# Altium schematic sheet GPRS.SchDoc(GPRS)
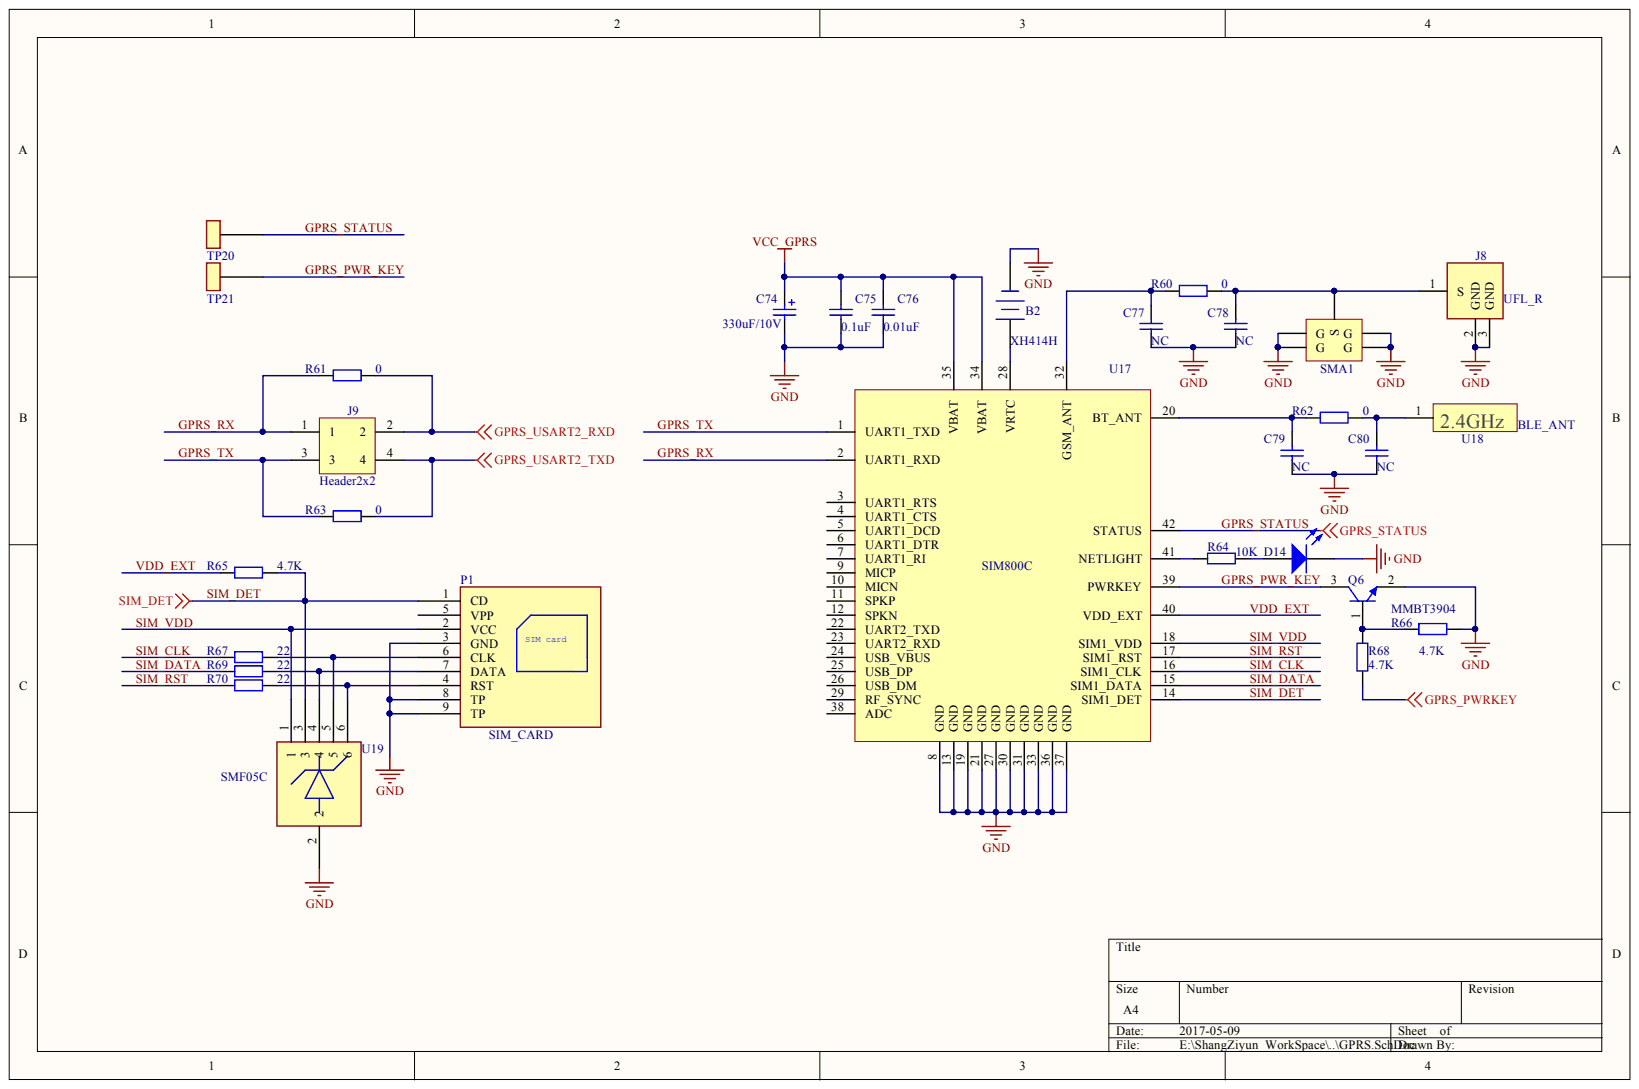
[diagram p0: GPRS.SchDoc]

## Parts
B2  B?  value XH414H  [XH414H]
C74  C?  value 330uF/10V  [EIA2816P]
C75  value 0.1uF  [0603C]  (hidden comment: Capacitor)
C76  value 0.01uF  [0603C]  (hidden comment: Capacitor)
C77  value NC  [0603C]  (hidden comment: Capacitor)
C78  value NC  [0603C]  (hidden comment: Capacitor)
C79  value NC  [0603C]  (hidden comment: Capacitor)
C80  value NC  [0603C]  (hidden comment: Capacitor)
D14  LED0  [LED_3mm]  (value not drawn)
J8  value UFL_R  [UFL_R_SMT]  (hidden comment: SMA_0)
J9  Header2x2  [DIP4]
P1  value SIM_CARD  [SIM060820MM-7P]  (hidden comment: SIM card_7P_ZT)
Q6  Q?  value MMBT3904  [SOT23]
R60  value 0  [L0603]  (hidden comment: Resistor)
R61  value 0  [L0603]  (hidden comment: Resistor)
R62  value 0  [L0603]  (hidden comment: Resistor)
R63  value 0  [L0603]  (hidden comment: Resistor)
R64  value 10K  [L0603]  (hidden comment: Resistor)
R65  value 4.7K  [L0603]  (hidden comment: Resistor)
R66  value 4.7K  [L0603]  (hidden comment: Resistor)
R67  value 22  [L0603]  (hidden comment: Resistor)
R68  value 4.7K  [L0603]  (hidden comment: Resistor)
R69  value 22  [L0603]  (hidden comment: Resistor)
R70  value 22  [L0603]  (hidden comment: Resistor)
SMA1  SMA  [SMA-KWE]
TP20  TEST POINT_0  [TP]  (value not drawn)
TP21  TEST POINT_0  [TP]  (value not drawn)
U17  SIM800C  [SIM800C]
U18  value BLE_ANT  [AN2051]  (hidden comment: ANT9520)
U19  SMF05C  [SC70-6L]

## Nets
GND: B2.2 C74.2 C75.2 C76.2 C77.2 C78.2 C79.2 C80.2 D14.2 J8.2 J8.3 P1.3(GND) P1.8(TP) P1.9(TP) Q6.2 R66.2 SMA1.1(G) SMA1.2(G) SMA1.3(G) SMA1.4(G) U17.8(GND) U17.13(GND) U17.19(GND) U17.21(GND) U17.27(GND) U17.30(GND) U17.31(GND) U17.33(GND) U17.36(GND) U17.37(GND) U19.2
GPRS_PWRKEY: R68.1
GPRS_USART2_RXD: J9.2 R61.2
GPRS_USART2_TXD: J9.4 R63.2
VCC_GPRS: C74.1 C75.1 C76.1 U17.34(VBAT) U17.35(VBAT)
GPRS_PWR_KEY: Q6.3 TP21.1 U17.39(PWRKEY)
GPRS_RX: J9.1 R61.1 U17.2(UART1_RXD)
GPRS_STATUS: TP20.1 U17.42(STATUS)
GPRS_TX: J9.3 R63.1 U17.1(UART1_TXD)
SIM_CLK: R67.1 U17.16(SIM1_CLK)
SIM_DATA: R69.1 U17.15(SIM1_DATA)
SIM_DET: P1.1(CD) R65.2 U17.14(SIM1_DET) U19.3
SIM_RST: R70.1 U17.17(SIM1_RST)
SIM_VDD: P1.2(VCC) U17.18(SIM1_VDD) U19.1
VDD_EXT: R65.1 U17.40(VDD_EXT)
NetB2_1: B2.1 U17.28(VRTC)
NetC77_1: C77.1 R60.1 U17.32(GSM_ANT)
NetC78_1: C78.1 J8.1 R60.2 SMA1.5(S)
NetC79_1: C79.1 R62.1 U17.20(BT_ANT)
NetC80_1: C80.1 R62.2 U18.1
NetD14_1: D14.1 R64.1
NetP1_4: P1.4(RST) R70.2 U19.6
NetP1_6: P1.6(CLK) R67.2 U19.5
NetP1_7: P1.7(DATA) R69.2 U19.4
NetQ6_1: Q6.1 R66.1 R68.2
NetR64_2: R64.2 U17.41(NETLIGHT)

Not connected: P1 5(VPP); U17 3(UART1_RTS) 4(UART1_CTS) 5(UART1_DCD) 6(UART1_DTR) 7(UART1_RI) 9(MICP) 10(MICN) 11(SPKP) 12(SPKN) 22(UART2_TXD) 23(UART2_RXD) 24(USB_VBUS) 25(USB_DP) 26(USB_DM) 29(RF_SYNC) 38(ADC)

## Library data of the parts
B2 = Battery: Component Kind: Standard; Library Name: MyLib.SCHLIB
C74 = Cap pol: Manufacturer PN: TAJA476M010R; Component Kind: Standard; Library Name: LNG.SCHLIB
C75-C80 = Cap: Component Kind: Standard; Description: Capacitor; LatestRevisionDate: 17-Jul-2002; LatestRevisionNote: Re-released for DXP Platform.; Library Name: Lib1.SCHLIB; PackageDescription: Radial Cap, Thru-Hole; 2 Leads; 0.3 in Pin Spacing; PackageReference: RAD-0.3; Published: 8-Jun-2000; Signal Integrity: Cap; Simulation: CAP; Publisher: Altium Limited
D14 = LED0805: Typical INFRARED GaAs LED; Value: LED_3mm_RED; Component Kind: Standard; LatestRevisionDate: 08-Jul-2005; LatestRevisionNote: Mech Layer 1 removed.; PackageDescription: LED; 2 Leads; PackageReference: LED-0; Published: 8-Jun-2000; Library Name: 简配版生物罐.SCHLIB; Publisher: Altium Limited
Q6 = NPN: Component Kind: Standard; Library Name: MyLib.SCHLIB
R60-R70 = Res: Component Kind: Standard; Library Name: Lib1.SCHLIB
SMA1 = SMA_5: Component Kind: Standard; Value: SMA; Library Name: LNG.SCHLIB
J8 = SMA_0: Component Kind: Standard; Library Name: MAINBOARD_Library.SchLib
J9 = Header2x2: Component Kind: Standard; Library Name: MyLib.SCHLIB; Value: Header2x2
P1 = SIM card_7P_ZT: Component Kind: Standard; Library Name: MAINBOARD_Library (2).SchLib
TP20 TP21 = TEST POINT_0: Component Kind: Standard; Library Name: MAINBOARD_Library.SchLib
U17 = SIM800C: Component Kind: Standard; Library Name: MyLib.SCHLIB; Value: SIM800C
U18 = ANT9520: Component Kind: Standard; Library Name: MyLib.SCHLIB
U19 = SMF05C: Component Kind: Standard; Library Name: MAINBOARD_Library.SchLib; Value: SMF05C
